# Altium schematic sheet Bridge.SchDoc(Bridge)
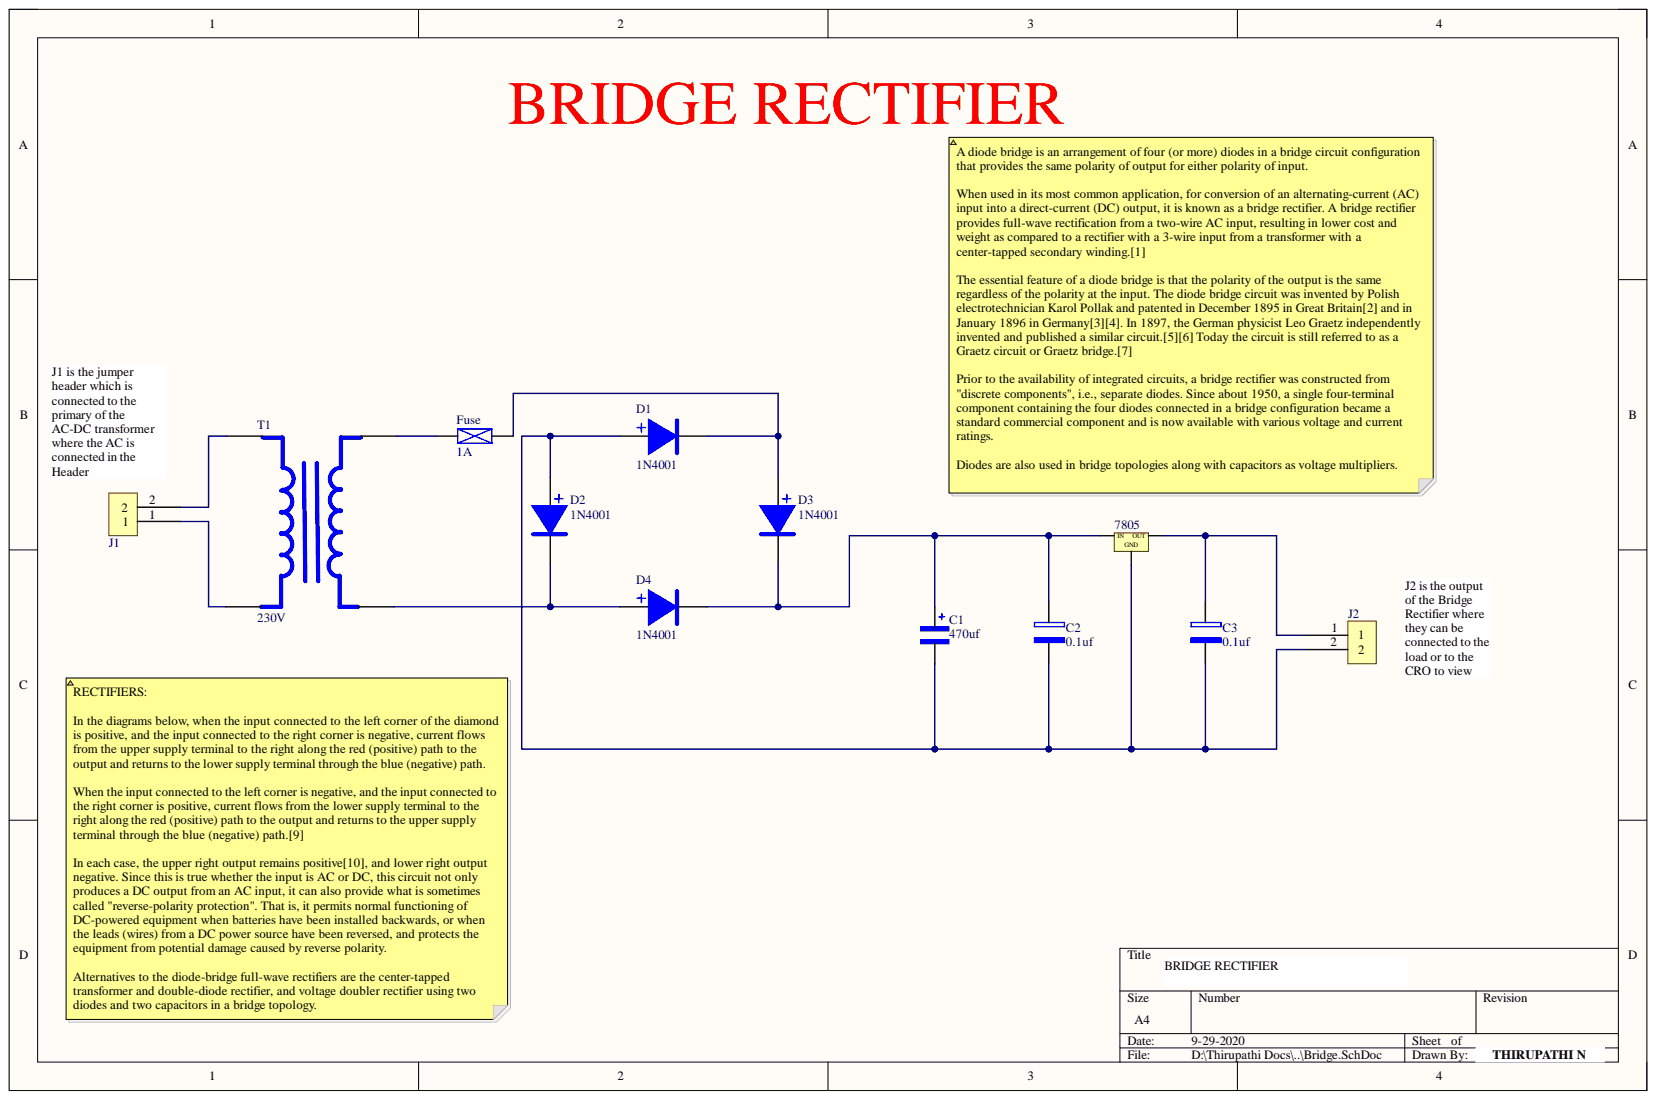
[diagram p0: Bridge.SchDoc]

## Parts
7805  L78M05CDT-TR  [7805]  (value not drawn)
C1  470uf  [470uf]
C2  0.1uf  [0.1uf]
C3  0.1uf  [0.1uf]
D1  1N4001  [D1N4007]
D2  1N4001  [D1N4007]
D3  1N4001  [D1N4007]
D4  1N4001  [D1N4007]
Fuse  1A  [fuse]
J1  Connector Type: Header  [Headder]  (hidden comment: 1986717-2)
J2  1986717-2  [Headder]  (value not drawn)
T1  230V  [230V AC]

## Nets
Net7805_1: 7805.1 C1.1 C2.2 D3.2 D4.2
Net7805_2: 7805.2 C1.2 C2.1 C3.1 D1.1 D2.1 J2.2
Net7805_3: 7805.3 C3.2 J2.1
NetD1_2: D1.2 D3.1 Fuse.1
NetD2_2: D2.2 D4.1 T1.4
NetFuse_2: Fuse.2 T1.3
NetJ1_1: J1.1 T1.2
NetJ1_2: J1.2 T1.1

## Library data of the parts
7805 = L78M05CDT-TR: STMICROELECTRONICS - L78M05CDT-TR - VOLTAGE REG, FIXED, 5V, 0.5A, TO-252-3; Dropout Voltage: 2V; Max Input Voltage: 35V; Max Output Current: 500mA; Min Input Voltage: 7V; Number of Outputs: 1; Polarity: Positive; Power Supply Rejection Ratio (PSRR): 62dB; Quiescent Current: 6mA; Tolerance: 5%; Case/Package: TO-252; Category: Voltage Regulators - Linear; Component Kind: Standard; ComponentLink1Description: https://datasheet.ciiva.com/4882/cd00000447-93067-4882369.pdf?src-supplier=Mouser; ComponentLink1URL: https://datasheet.ciiva.com/4882/cd00000447-93067-4882369.pdf?src-supplier=Mouser; ComponentLink2Description: https://datasheet.ciiva.com/15111/getdatasheetpartid-111001-15111751.pdf?src-supplier=Verical; ComponentLink2URL: https://datasheet.ciiva.com/15111/getdatasheetpartid-111001-15111751.pdf?src-supplier=Verical; ComponentLink3Description: https://datasheet.ciiva.com/34303/2021024-34303902.pdf?src-supplier=Jameco; ComponentLink3URL: https://datasheet.ciiva.com/34303/2021024-34303902.pdf?src-supplier=Jameco; ComponentLink4Description: https://datasheet.ciiva.com/16443/cd00000447-16443381.pdf?src-supplier=Future+Electronics; ComponentLink4URL: https://datasheet.ciiva.com/16443/cd00000447-16443381.pdf?src-supplier=Future+Electronics; ComponentLink5Description: https://datasheet.ciiva.com/2041/cd00000447-2041990.pdf?src-supplier=Digi-Key; ComponentLink5URL: https://datasheet.ciiva.com/2041/cd00000447-2041990.pdf?src-supplier=Digi-Key; ComponentLink6Description: https://datasheet.ciiva.com/2041/cd00000447-2041991.pdf?src-supplier=Digi-Key; ComponentLink6URL: https://datasheet.ciiva.com/2041/cd00000447-2041991.pdf?src-supplier=Digi-Key; ComponentLink7Description: https://datasheet.ciiva.com/2041/cd00000447-2041992.pdf?src-supplier=Digi-Key; ComponentLink7URL: https://datasheet.ciiva.com/2041/cd00000447-2041992.pdf?src-supplier=Digi-Key; ComponentLink8Description: https://datasheet.ciiva.com/14111/tbl78mxxc-data-e-14111354.pdf?src-supplier=Distrelec; ComponentLink8URL: https://datasheet.ciiva.com/14111/tbl78mxxc-data-e-14111354.pdf?src-supplier=Distrelec; ComponentLink9Description: https://datasheet.ciiva.com/1512/1834365-1512349.pdf?src-supplier=Element14; ComponentLink9URL: https://datasheet.ciiva.com/1512/1834365-1512349.pdf?src-supplier=Element14; ComponentLink10Description: https://datasheet.ciiva.com/46425/1834365-46425535.pdf?src-supplier=Element14; ComponentLink10URL: https://datasheet.ciiva.com/46425/1834365-46425535.pdf?src-supplier=Element14; ComponentLink11Description: https://datasheet.ciiva.com/41439/001184659-da-01-en-ic-reg-ldo-5v-l78m05cdt-tr-to-252-3-stm-41439772.pdf?src-supplier=Conrad; ComponentLink11URL: https://datasheet.ciiva.com/41439/001184659-da-01-en-ic-reg-ldo-5v-l78m05cdt-tr-to-252-3-stm-41439772.pdf?src-supplier=Conrad; Contact Plating: Tin,Matte; Height: 2.4mm; Lead Free: Lead Free; Length: 6.6mm; Library Name: Bridge.SchLib; Manufacturer: STMicroelectronics; Manufacturer Part Number: L78M05CDT-TR; Max Operating Temperature: 150°C; Max Output Voltage: 5V; Min Operating Temperature: 0°C; Min Output Voltage: 5V; Mount: Surface Mount; Nominal Output Voltage: 5V; Number of Pins: 3; Number of Regulators: 1; Output Current: 500mA; Output Type: Fixed; Output Voltage: 5V; Package Quantity: 1; Packaging: Tape and Reel; Pricing 1: 1=0.46000, 10=0.39200, 25=0.36560, 100=0.27170, 250=0.22992, 500=0.21946, 1000=0.16198 (USD); Radiation Hardening: No; REACH SVHC: No SVHC; RoHS: Yes; Stock 1: 33280; Supplier 1: Digi-Key; Supplier Part Number 1: 497-1203-1-ND; Weight: 0.01lb; Width: 6.2mm
C1 = 470uf: CAP CER 470UF 4V X5R 1812; Manufacturer: Taiyo Yuden; Manufacturer Part Number: AMK432BJ477MM-T; Tolerance: 20%; Capacitance: 470uF; Case Code (Imperial): 1812; Case Code (Metric): 4532; Case/Package: 1812; Category: Ceramic Capacitors; Component Kind: Standard; ComponentLink1Description: https://media.digikey.com/pdf/Data%20Sheets/Taiyo%20Yuden%20PDFs%20URL%20links/AMK432BJ477MM_Spec.PDF?src-supplier=Digi-Key; ComponentLink1URL: https://media.digikey.com/pdf/Data%20Sheets/Taiyo%20Yuden%20PDFs%20URL%20links/AMK432BJ477MM_Spec.PDF?src-supplier=Digi-Key; Lead Free: Lead Free; Length: 0.177inch; Library Name: Bridge.SchLib; Max Operating Temperature: 85°C; Min Operating Temperature: -55°C; Mount: Surface Mount; Packaging: Tape and Reel; Pricing 1: 1=5.94000, 10=5.79200, 50=5.19760, 100=4.75200 (USD); RoHS: Yes; Stock 1: 613; Supplier 1: Digi-Key; Supplier Part Number 1: 587-4368-1-ND; Thickness: 0.106inch; Voltage Rating: 4V; Width: 0.126inch
C2 C3 = CC0402ZRY5V7BB104: Multilayer Ceramic Capacitors MLCC - SMD/SMT 100nF 16V Y5V -20/+80%; Manufacturer: Yageo; Insulation Resistance: 100GR; Tolerance: 80%; Capacitance: 100nF; Case Code (Imperial): 0402; Case Code (Metric): 1005; Case/Package: 0402; Category: Ceramic Capacitors; Component Kind: Standard; ComponentLink1Description: https://datasheet.ciiva.com/26862/getdatasheetpartid-403483-26862665.pdf?src-supplier=Verical; ComponentLink1URL: https://datasheet.ciiva.com/26862/getdatasheetpartid-403483-26862665.pdf?src-supplier=Verical; ComponentLink2Description: https://datasheet.ciiva.com/143/mlccorderingcode-143947.pdf?src-supplier=Digi-Key; ComponentLink2URL: https://datasheet.ciiva.com/143/mlccorderingcode-143947.pdf?src-supplier=Digi-Key; ComponentLink3Description: https://datasheet.ciiva.com/143/mlccorderingcode-143948.pdf?src-supplier=Digi-Key; ComponentLink3URL: https://datasheet.ciiva.com/143/mlccorderingcode-143948.pdf?src-supplier=Digi-Key; ComponentLink4Description: https://datasheet.ciiva.com/143/mlccorderingcode-143949.pdf?src-supplier=Digi-Key; ComponentLink4URL: https://datasheet.ciiva.com/143/mlccorderingcode-143949.pdf?src-supplier=Digi-Key; ComponentLink5Description: https://datasheet.ciiva.com/11586/upy-gphc-y5v-6-3v-to-50v-5-11586519.pdf?src-supplier=Avnet; ComponentLink5URL: https://datasheet.ciiva.com/11586/upy-gphc-y5v-6-3v-to-50v-5-11586519.pdf?src-supplier=Avnet; ComponentLink6Description: https://datasheet.ciiva.com/28450/1132289-28450424.pdf?src-supplier=Element14; ComponentLink6URL: https://datasheet.ciiva.com/28450/1132289-28450424.pdf?src-supplier=Element14; ComponentLink7Description: https://datasheet.ciiva.com/7978/1132289-7978122.pdf?src-supplier=Element14; ComponentLink7URL: https://datasheet.ciiva.com/7978/1132289-7978122.pdf?src-supplier=Element14; ComponentLink8Description: https://datasheet.ciiva.com/8033/1132289-8033645.pdf?src-supplier=Element14; ComponentLink8URL: https://datasheet.ciiva.com/8033/1132289-8033645.pdf?src-supplier=Element14; ComponentLink9Description: https://datasheet.ciiva.com/8033/1132289-8033647.pdf?src-supplier=Element14; ComponentLink9URL: https://datasheet.ciiva.com/8033/1132289-8033647.pdf?src-supplier=Element14; ComponentLink10Description: https://datasheet.ciiva.com/13386/upy-gphc-y5v-6-3v-to-50v-5-13386335.pdf?src-supplier=Mouser; ComponentLink10URL: https://datasheet.ciiva.com/13386/upy-gphc-y5v-6-3v-to-50v-5-13386335.pdf?src-supplier=Mouser; Depth: 0.5mm; Dielectric: Y5V; Halogen Free: Halogen Free; Height: 0.5mm; Lead Free: Lead Free; Length: 1mm; Library Name: Bridge.SchLib; Manufacturer Part Number: CC0402ZRY5V7BB104; Max Operating Temperature: 85°C; Min Operating Temperature: -30°C; Mount: Surface Mount; Package Quantity: 10000; Packaging: Tape and Reel; Pricing 1: 1=0.10000, 10=0.01900, 50=0.01020, 100=0.00830, 500=0.00592, 1000=0.00466, 2500=0.00424, 5000=0.00390 (USD); Radiation Hardening: No; REACH SVHC: No SVHC; Resistor Type: Ceramic; RoHS: Yes; Stock 1: 3045044; Supplier 1: Digi-Key; Supplier Part Number 1: 311-1047-1-ND; Termination: SMD/SMT; Thickness: 0.022inch; Voltage Rating: 16V; Voltage Rating (DC): 16V; Width: 0.02inch
D1-D4 = 1N4001: DIODE GEN PURP 50V 1A DO41; Manufacturer: ON Semiconductor / Fairchild; Capacitance: 15pF; Element Configuration: Single; Forward Voltage: 1.1V; Max Forward Surge Current (Ifsm): 30A; Max Reverse Current: 5uA; Polarity: Standard; Power Dissipation: 3W; Average Rectified Current: 1A; Category: Rectifier Diodes; Component Kind: Standard; ComponentLink1Description: https://datasheet.ciiva.com/910/1n4001-910352.pdf?src-supplier=Digi-Key; ComponentLink1URL: https://datasheet.ciiva.com/910/1n4001-910352.pdf?src-supplier=Digi-Key; ComponentLink2Description: https://datasheet.ciiva.com/910/1n4001-910352.pdf?src-supplier=Avnet; ComponentLink2URL: https://datasheet.ciiva.com/910/1n4001-910352.pdf?src-supplier=Avnet; ComponentLink3Description: https://datasheet.ciiva.com/4452/1n4004-81693-4452416.pdf?src-supplier=Mouser; ComponentLink3URL: https://datasheet.ciiva.com/4452/1n4004-81693-4452416.pdf?src-supplier=Mouser; ComponentLink4Description: https://datasheet.ciiva.com/30479/0900766b810c07cd-30479188.pdf?src-supplier=RSComponents; ComponentLink4URL: https://datasheet.ciiva.com/30479/0900766b810c07cd-30479188.pdf?src-supplier=RSComponents; ComponentLink5Description: https://datasheet.ciiva.com/910/1n4001-910353.pdf?src-supplier=Digi-Key; ComponentLink5URL: https://datasheet.ciiva.com/910/1n4001-910353.pdf?src-supplier=Digi-Key; ComponentLink6Description: https://datasheet.ciiva.com/927/1n4001-d-927540.pdf?src-supplier=Digi-Key; ComponentLink6URL: https://datasheet.ciiva.com/927/1n4001-d-927540.pdf?src-supplier=Digi-Key; ComponentLink7Description: https://datasheet.ciiva.com/22685/1504060-22685765.pdf?src-supplier=Element14; ComponentLink7URL: https://datasheet.ciiva.com/22685/1504060-22685765.pdf?src-supplier=Element14; ComponentLink8Description: https://datasheet.ciiva.com/15208/getdatasheetpartid-148723-15208346.pdf?src-supplier=Verical; ComponentLink8URL: https://datasheet.ciiva.com/15208/getdatasheetpartid-148723-15208346.pdf?src-supplier=Verical; ComponentLink9Description: https://datasheet.ciiva.com/8084/1n4007-8084076.pdf?src-supplier=Avnet; ComponentLink9URL: https://datasheet.ciiva.com/8084/1n4007-8084076.pdf?src-supplier=Avnet; ComponentLink10Description: https://datasheet.ciiva.com/22651/1923868-22651932.pdf?src-supplier=Element14; ComponentLink10URL: https://datasheet.ciiva.com/22651/1923868-22651932.pdf?src-supplier=Element14; Contact Plating: Tin,Matte; Current Rating: 1A; Diameter: 2.72mm; Forward Current: 1A; Height: 5.2mm; Lead Free: Lead Free; Length: 5.2mm; Library Name: Bridge.SchLib; Manufacturer Part Number: 1N4001; Max Operating Temperature: 175°C; Max Repetitive Reverse Voltage (Vrrm): 50V; Max Reverse Voltage (DC): 50V; Max Surge Current: 30A; Min Operating Temperature: -55°C; Mount: Through Hole; Number of Pins: 2; Output Current: 1A; Package Quantity: 1000; Packaging: Tape and Reel; Peak Non-Repetitive Surge Current: 30A; Pricing 1: 25000=0.01307, 26000=0.01280, 51000=0.01260, 130000=0.01240, 250000=0.01220 (USD); Radiation Hardening: No; REACH SVHC: No SVHC; Reverse Voltage: 50V; RoHS: Yes; Supplier 1: Avnet; Supplier Part Number 1: 1N4001; Voltage Rating (DC): 50V; Weight: 0.245g; Width: 0.25inch
Fuse = 0679L1000-01: FUSE BRD MNT 1A 125VAC/VDC; Manufacturer: Bel; Approvals: cURus; DC Cold Resistance: 90mR; Fuse Type: Board Mount (Cartridge Style Excluded); Voltage Rating (AC): 125V; Case Code (Imperial): 2410; Case Code (Metric): 6125; Case/Package: 2410; Category: Fuses; Component Kind: Standard; ComponentLink1Description: http://www.belfuse.com/resources/CircuitProtection/datasheets/0679L%20Sep2016.pdf?src-supplier=Digi-Key; ComponentLink1URL: http://www.belfuse.com/resources/CircuitProtection/datasheets/0679L%20Sep2016.pdf?src-supplier=Digi-Key; ComponentLink2Description: https://www.belfuse.com/resources/CircuitProtection/datasheets/0679L%20Sep2016.pdf?src-supplier=Digi-Key; ComponentLink2URL: https://www.belfuse.com/resources/CircuitProtection/datasheets/0679L%20Sep2016.pdf?src-supplier=Digi-Key; Current Rating: 1A; Height: 0.11inch; Length: 0.24inch; Library Name: Bridge.SchLib; Manufacturer Part Number: 0679L1000-01; Max Operating Temperature: 125°C; Min Operating Temperature: -55°C; Mount: Surface Mount; Packaging: Tape and Reel; Pricing 1: 1=0.32000, 5=0.31000, 10=0.29600, 50=0.26400, 100=0.24570, 250=0.21840, 500=0.18200 (USD); RoHS: Yes; Stock 1: 434; Supplier 1: Digi-Key; Supplier Part Number 1: 507-1965-1-ND; Voltage Rating (DC): 125V; Width: 0.1inch
J1 = 1986717-2: Headers & Wire Housings UNSHRDED STD HDR 3.5MM 2POS; Manufacturer: TE Connectivity; Contact Resistance: 15mR; Current: 2A; Interlock: No (Without); Number of Contacts: 2; Number of Levels: 1; Pin Length: 0.275inch; Plating Thickness: 3um; Screwless Terminal Block: Yes (With); Voltage: 150V; Voltage Rating: 130V; Category: Headers and Wire Housings; Color: Green; Component Kind: Standard; ComponentLink1Description: https://datasheet.ciiva.com/9465/eng-cd-1986717-b1-9465729.pdf?src-supplier=Avnet; ComponentLink1URL: https://datasheet.ciiva.com/9465/eng-cd-1986717-b1-9465729.pdf?src-supplier=Avnet; ComponentLink2Description: https://datasheet.ciiva.com/30492/0900766b81226684-30492513.pdf?src-supplier=RSComponents; ComponentLink2URL: https://datasheet.ciiva.com/30492/0900766b81226684-30492513.pdf?src-supplier=RSComponents; ComponentLink3Description: https://datasheet.ciiva.com/7624/1651060-7624901.pdf?src-supplier=Element14; ComponentLink3URL: https://datasheet.ciiva.com/7624/1651060-7624901.pdf?src-supplier=Element14; ComponentLink4Description: https://datasheet.ciiva.com/36854/eng-ds-5-1773463-2-flush-mount-spring-type-0312-36854555.pdf?src-supplier=Onlinecomponents.com; ComponentLink4URL: https://datasheet.ciiva.com/36854/eng-ds-5-1773463-2-flush-mount-spring-type-0312-36854555.pdf?src-supplier=Onlinecomponents.com; ComponentLink5Description: https://datasheet.ciiva.com/6746/eng-cd-1986717-b2-6746909.pdf?src-supplier=Digi-Key; ComponentLink5URL: https://datasheet.ciiva.com/6746/eng-cd-1986717-b2-6746909.pdf?src-supplier=Digi-Key; Contact Gender: Male; Contact Material: Copper Alloy; Contact Plating: Tin; Current Rating: 2A; Depth: 2.8mm; ELV: Compliant; Housing Color: Green; Housing Material: Polyamide; Length: 8.58mm; Library Name: Bridge.SchLib; Manufacturer Part Number: 1986717-2; Max Current Rating: 2A; Max Operating Temperature: 110°C; Min Operating Temperature: -40°C; Mount: Through Hole; Number of Poles: 2; Number of Positions: 2; Number of Positions Per Level: 2; Number of Rows: 1; Orientation: Straight; Package Quantity: 300; Packaging: Bulk; Pitch: 3.5mm; Pricing 1: 1=0.74000, 10=0.46000, 25=0.39360, 50=0.35360, 100=0.34630, 250=0.29476, 500=0.28000, 1000=0.25053, 5000=0.19600 (USD); Radiation Hardening: No; REACH SVHC: Unknown; RoHS: Yes; Screw Flange: No (Without); Shrouded: No (Without); Side Stackable: No (Without); Stock 1: 98; Supplier 1: Digi-Key; Supplier Part Number 1: A104641-ND; Tail Length: 3.5mm; Termination: Solder
J2 = 1986717-2: Headers & Wire Housings UNSHRDED STD HDR 3.5MM 2POS; Manufacturer: TE Connectivity; Connector Type: Header; Contact Resistance: 15mR; Current: 2A; Interlock: No (Without); Number of Contacts: 2; Number of Levels: 1; Pin Length: 0.275inch; Plating Thickness: 3um; Screwless Terminal Block: Yes (With); Voltage: 150V; Voltage Rating: 130V; Category: Headers and Wire Housings; Color: Green; Component Kind: Standard; ComponentLink1Description: https://datasheet.ciiva.com/9465/eng-cd-1986717-b1-9465729.pdf?src-supplier=Avnet; ComponentLink1URL: https://datasheet.ciiva.com/9465/eng-cd-1986717-b1-9465729.pdf?src-supplier=Avnet; ComponentLink2Description: https://datasheet.ciiva.com/30492/0900766b81226684-30492513.pdf?src-supplier=RSComponents; ComponentLink2URL: https://datasheet.ciiva.com/30492/0900766b81226684-30492513.pdf?src-supplier=RSComponents; ComponentLink3Description: https://datasheet.ciiva.com/7624/1651060-7624901.pdf?src-supplier=Element14; ComponentLink3URL: https://datasheet.ciiva.com/7624/1651060-7624901.pdf?src-supplier=Element14; ComponentLink4Description: https://datasheet.ciiva.com/36854/eng-ds-5-1773463-2-flush-mount-spring-type-0312-36854555.pdf?src-supplier=Onlinecomponents.com; ComponentLink4URL: https://datasheet.ciiva.com/36854/eng-ds-5-1773463-2-flush-mount-spring-type-0312-36854555.pdf?src-supplier=Onlinecomponents.com; ComponentLink5Description: https://datasheet.ciiva.com/6746/eng-cd-1986717-b2-6746909.pdf?src-supplier=Digi-Key; ComponentLink5URL: https://datasheet.ciiva.com/6746/eng-cd-1986717-b2-6746909.pdf?src-supplier=Digi-Key; Contact Gender: Male; Contact Material: Copper Alloy; Contact Plating: Tin; Current Rating: 2A; Depth: 2.8mm; ELV: Compliant; Housing Color: Green; Housing Material: Polyamide; Length: 8.58mm; Library Name: Bridge.SchLib; Manufacturer Part Number: 1986717-2; Max Current Rating: 2A; Max Operating Temperature: 110°C; Min Operating Temperature: -40°C; Mount: Through Hole; Number of Poles: 2; Number of Positions: 2; Number of Positions Per Level: 2; Number of Rows: 1; Orientation: Straight; Package Quantity: 300; Packaging: Bulk; Pitch: 3.5mm; Pricing 1: 1=0.74000, 10=0.46000, 25=0.39360, 50=0.35360, 100=0.34630, 250=0.29476, 500=0.28000, 1000=0.25053, 5000=0.19600 (USD); Radiation Hardening: No; REACH SVHC: Unknown; RoHS: Yes; Screw Flange: No (Without); Shrouded: No (Without); Side Stackable: No (Without); Stock 1: 98; Supplier 1: Digi-Key; Supplier Part Number 1: A104641-ND; Tail Length: 3.5mm; Termination: Solder
T1 = RAC05-12SK/277: AC-DC; Converter; 4.2kV reg; Single Output; 5W; 12V Out; RAC05-K/277 Series; Manufacturer: Recom; Approvals: CE,cURus; Efficiency: 83%; Max Output Current: 416mA; Number of Outputs: 1; Category: Voltage Regulators - Switching; Component Kind: Standard; ComponentLink1Description: https://www.recom-power.com/pdf/Powerline_AC-DC/RAC05-K_277.pdf?src-supplier=Digi-Key; ComponentLink1URL: https://www.recom-power.com/pdf/Powerline_AC-DC/RAC05-K_277.pdf?src-supplier=Digi-Key; Height: 21.8mm; Length: 31.7mm; Library Name: Bridge.SchLib; Manufacturer Part Number: RAC05-12SK/277; Max Operating Temperature: 85°C; Max Output Voltage: 12V; Max Power Dissipation: 5W; Min Operating Temperature: -40°C; Mount: Through Hole; Pricing 1: 1=10.15000, 5=10.00600, 10=9.86000, 25=9.28000, 50=8.99000, 100=8.70000, 250=8.41000, 500=8.12000 (USD); RoHS: No; Stock 1: 71; Supplier 1: Digi-Key; Supplier Part Number 1: 945-3192-ND; Voltage - Output 1: 12V; Weight: 31.5g; Width: 26.7mm
